# Altium schematic sheet Esquematico LEDS.SchDoc("Esquematico LEDS")
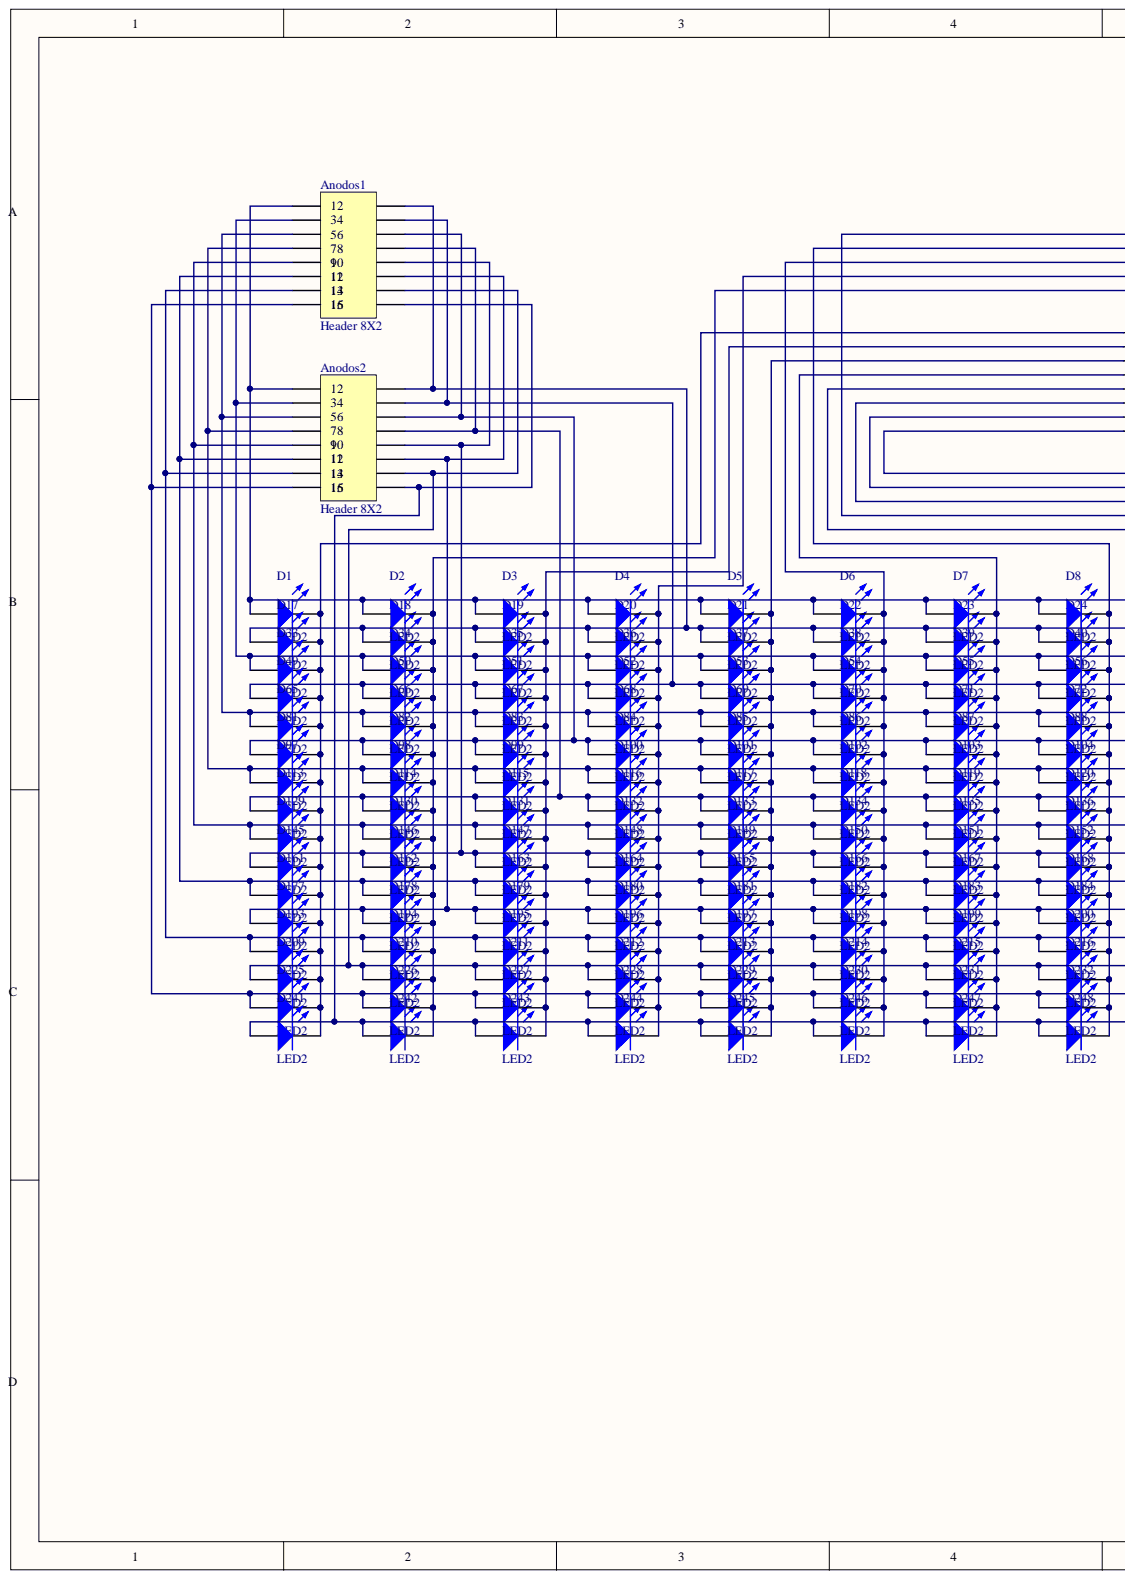
[diagram p0: Esquematico LEDS.SchDoc, left]
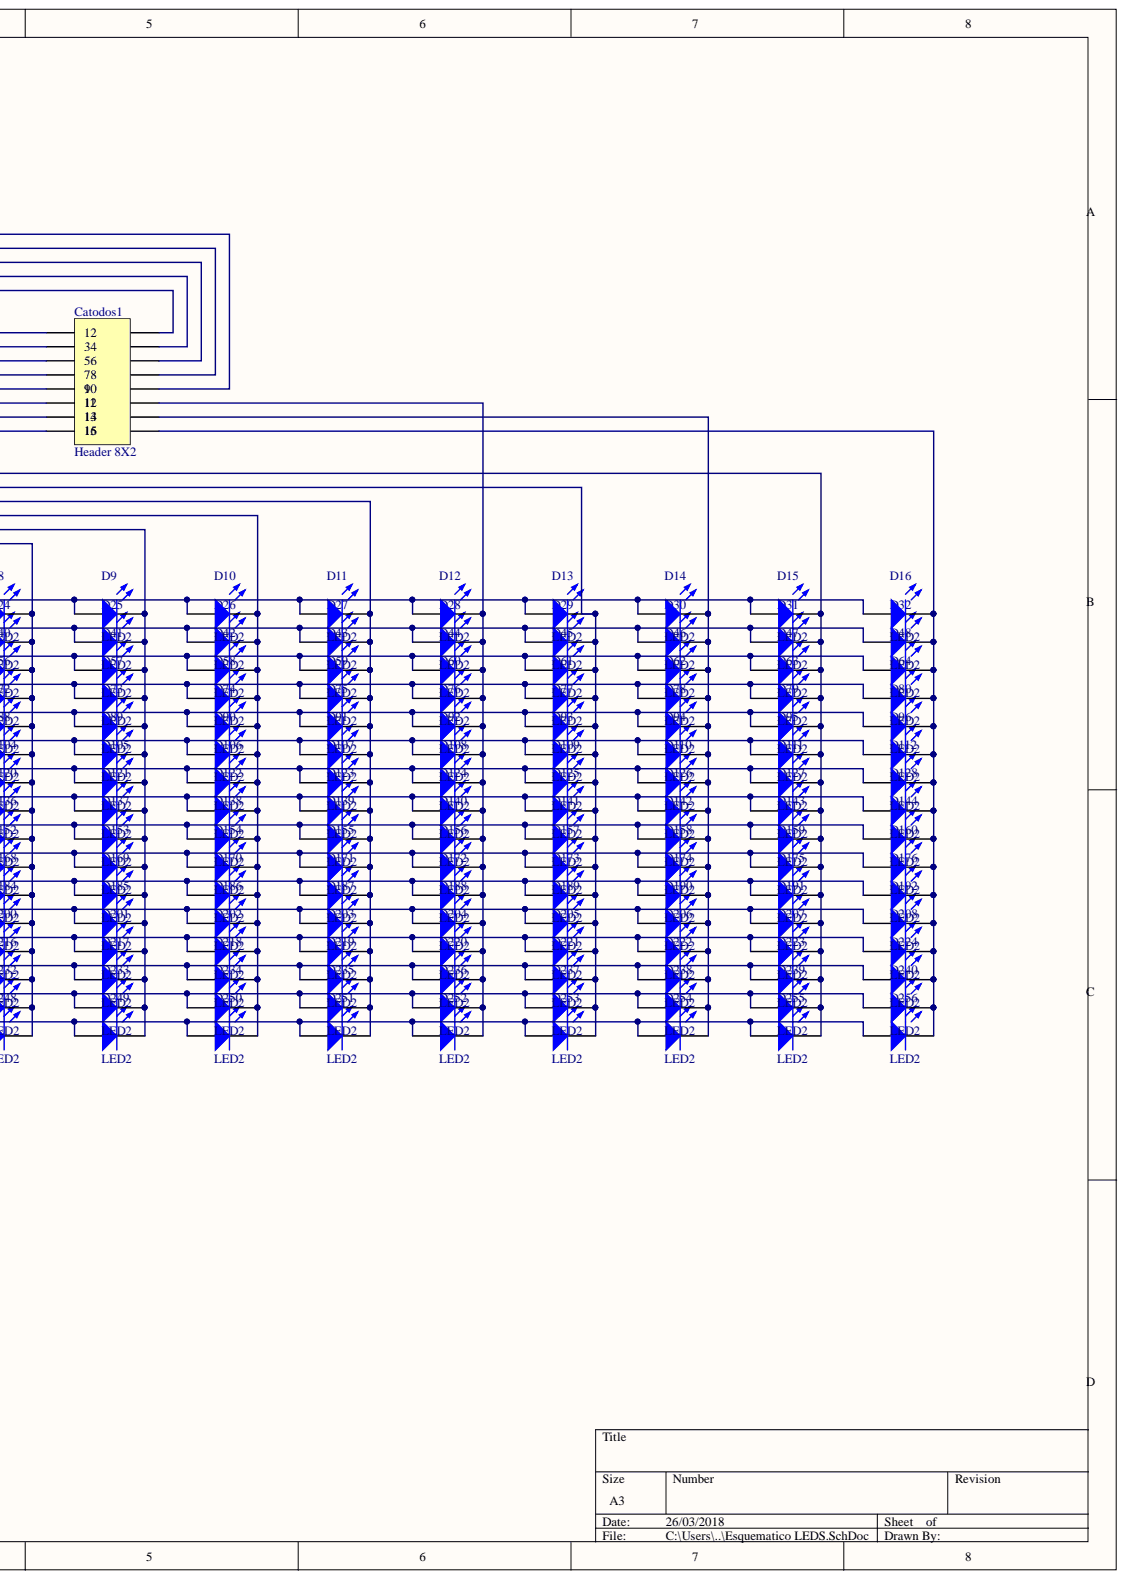
[diagram p1: Esquematico LEDS.SchDoc, right]

## Parts
Anodos1  Header 8X2  [HDR2X8]
Anodos2  Header 8X2  [HDR2X8]
Catodos1  Header 8X2  [HDR2X8]
D1  LED2  [3.2X1.6X1.1]
D2  LED2  [3.2X1.6X1.1]
D3  LED2  [3.2X1.6X1.1]
D4  LED2  [3.2X1.6X1.1]
D5  LED2  [3.2X1.6X1.1]
D6  LED2  [3.2X1.6X1.1]
D7  LED2  [3.2X1.6X1.1]
D8  LED2  [3.2X1.6X1.1]
D9  LED2  [3.2X1.6X1.1]
D10  LED2  [3.2X1.6X1.1]
D11  LED2  [3.2X1.6X1.1]
D12  LED2  [3.2X1.6X1.1]
D13  LED2  [3.2X1.6X1.1]
D14  LED2  [3.2X1.6X1.1]
D15  LED2  [3.2X1.6X1.1]
D16  LED2  [3.2X1.6X1.1]
D17  LED2  [3.2X1.6X1.1]
D18  LED2  [3.2X1.6X1.1]
D19  LED2  [3.2X1.6X1.1]
D20  LED2  [3.2X1.6X1.1]
D21  LED2  [3.2X1.6X1.1]
D22  LED2  [3.2X1.6X1.1]
D23  LED2  [3.2X1.6X1.1]
D24  LED2  [3.2X1.6X1.1]
D25  LED2  [3.2X1.6X1.1]
D26  LED2  [3.2X1.6X1.1]
D27  LED2  [3.2X1.6X1.1]
D28  LED2  [3.2X1.6X1.1]
D29  LED2  [3.2X1.6X1.1]
D30  LED2  [3.2X1.6X1.1]
D31  LED2  [3.2X1.6X1.1]
D32  LED2  [3.2X1.6X1.1]
D33  LED2  [3.2X1.6X1.1]
D34  LED2  [3.2X1.6X1.1]
D35  LED2  [3.2X1.6X1.1]
D36  LED2  [3.2X1.6X1.1]
D37  LED2  [3.2X1.6X1.1]
D38  LED2  [3.2X1.6X1.1]
D39  LED2  [3.2X1.6X1.1]
D40  LED2  [3.2X1.6X1.1]
D41  LED2  [3.2X1.6X1.1]
D42  LED2  [3.2X1.6X1.1]
D43  LED2  [3.2X1.6X1.1]
D44  LED2  [3.2X1.6X1.1]
D45  LED2  [3.2X1.6X1.1]
D46  LED2  [3.2X1.6X1.1]
D47  LED2  [3.2X1.6X1.1]
D48  LED2  [3.2X1.6X1.1]
D49  LED2  [3.2X1.6X1.1]
D50  LED2  [3.2X1.6X1.1]
D51  LED2  [3.2X1.6X1.1]
D52  LED2  [3.2X1.6X1.1]
D53  LED2  [3.2X1.6X1.1]
D54  LED2  [3.2X1.6X1.1]
D55  LED2  [3.2X1.6X1.1]
D56  LED2  [3.2X1.6X1.1]
D57  LED2  [3.2X1.6X1.1]
D58  LED2  [3.2X1.6X1.1]
D59  LED2  [3.2X1.6X1.1]
D60  LED2  [3.2X1.6X1.1]
D61  LED2  [3.2X1.6X1.1]
D62  LED2  [3.2X1.6X1.1]
D63  LED2  [3.2X1.6X1.1]
D64  LED2  [3.2X1.6X1.1]
D65  LED2  [3.2X1.6X1.1]
D66  LED2  [3.2X1.6X1.1]
D67  LED2  [3.2X1.6X1.1]
D68  LED2  [3.2X1.6X1.1]
D69  LED2  [3.2X1.6X1.1]
D70  LED2  [3.2X1.6X1.1]
D71  LED2  [3.2X1.6X1.1]
D72  LED2  [3.2X1.6X1.1]
D73  LED2  [3.2X1.6X1.1]
D74  LED2  [3.2X1.6X1.1]
D75  LED2  [3.2X1.6X1.1]
D76  LED2  [3.2X1.6X1.1]
D77  LED2  [3.2X1.6X1.1]
D78  LED2  [3.2X1.6X1.1]
D79  LED2  [3.2X1.6X1.1]
D80  LED2  [3.2X1.6X1.1]
D81  LED2  [3.2X1.6X1.1]
D82  LED2  [3.2X1.6X1.1]
D83  LED2  [3.2X1.6X1.1]
D84  LED2  [3.2X1.6X1.1]
D85  LED2  [3.2X1.6X1.1]
D86  LED2  [3.2X1.6X1.1]
D87  LED2  [3.2X1.6X1.1]
D88  LED2  [3.2X1.6X1.1]
D89  LED2  [3.2X1.6X1.1]
D90  LED2  [3.2X1.6X1.1]
D91  LED2  [3.2X1.6X1.1]
D92  LED2  [3.2X1.6X1.1]
D93  LED2  [3.2X1.6X1.1]
D94  LED2  [3.2X1.6X1.1]
D95  LED2  [3.2X1.6X1.1]
D96  LED2  [3.2X1.6X1.1]
D97  LED2  [3.2X1.6X1.1]
D98  LED2  [3.2X1.6X1.1]
D99  LED2  [3.2X1.6X1.1]
D100  LED2  [3.2X1.6X1.1]
D101  LED2  [3.2X1.6X1.1]
D102  LED2  [3.2X1.6X1.1]
D103  LED2  [3.2X1.6X1.1]
D104  LED2  [3.2X1.6X1.1]
D105  LED2  [3.2X1.6X1.1]
D106  LED2  [3.2X1.6X1.1]
D107  LED2  [3.2X1.6X1.1]
D108  LED2  [3.2X1.6X1.1]
D109  LED2  [3.2X1.6X1.1]
D110  LED2  [3.2X1.6X1.1]
D111  LED2  [3.2X1.6X1.1]
D112  LED2  [3.2X1.6X1.1]
D113  LED2  [3.2X1.6X1.1]
D114  LED2  [3.2X1.6X1.1]
D115  LED2  [3.2X1.6X1.1]
D116  LED2  [3.2X1.6X1.1]
D117  LED2  [3.2X1.6X1.1]
D118  LED2  [3.2X1.6X1.1]
D119  LED2  [3.2X1.6X1.1]
D120  LED2  [3.2X1.6X1.1]
D121  LED2  [3.2X1.6X1.1]
D122  LED2  [3.2X1.6X1.1]
D123  LED2  [3.2X1.6X1.1]
D124  LED2  [3.2X1.6X1.1]
D125  LED2  [3.2X1.6X1.1]
D126  LED2  [3.2X1.6X1.1]
D127  LED2  [3.2X1.6X1.1]
D128  LED2  [3.2X1.6X1.1]
D129  LED2  [3.2X1.6X1.1]
D130  LED2  [3.2X1.6X1.1]
D131  LED2  [3.2X1.6X1.1]
D132  LED2  [3.2X1.6X1.1]
D133  LED2  [3.2X1.6X1.1]
D134  LED2  [3.2X1.6X1.1]
D135  LED2  [3.2X1.6X1.1]
D136  LED2  [3.2X1.6X1.1]
D137  LED2  [3.2X1.6X1.1]
D138  LED2  [3.2X1.6X1.1]
D139  LED2  [3.2X1.6X1.1]
D140  LED2  [3.2X1.6X1.1]
D141  LED2  [3.2X1.6X1.1]
D142  LED2  [3.2X1.6X1.1]
D143  LED2  [3.2X1.6X1.1]
D144  LED2  [3.2X1.6X1.1]
D145  LED2  [3.2X1.6X1.1]
D146  LED2  [3.2X1.6X1.1]
D147  LED2  [3.2X1.6X1.1]
D148  LED2  [3.2X1.6X1.1]
D149  LED2  [3.2X1.6X1.1]
D150  LED2  [3.2X1.6X1.1]
D151  LED2  [3.2X1.6X1.1]
D152  LED2  [3.2X1.6X1.1]
D153  LED2  [3.2X1.6X1.1]
D154  LED2  [3.2X1.6X1.1]
D155  LED2  [3.2X1.6X1.1]
D156  LED2  [3.2X1.6X1.1]
D157  LED2  [3.2X1.6X1.1]
D158  LED2  [3.2X1.6X1.1]
D159  LED2  [3.2X1.6X1.1]
D160  LED2  [3.2X1.6X1.1]
D161  LED2  [3.2X1.6X1.1]
D162  LED2  [3.2X1.6X1.1]
D163  LED2  [3.2X1.6X1.1]
D164  LED2  [3.2X1.6X1.1]
D165  LED2  [3.2X1.6X1.1]
D166  LED2  [3.2X1.6X1.1]
D167  LED2  [3.2X1.6X1.1]
D168  LED2  [3.2X1.6X1.1]
D169  LED2  [3.2X1.6X1.1]
D170  LED2  [3.2X1.6X1.1]
D171  LED2  [3.2X1.6X1.1]
D172  LED2  [3.2X1.6X1.1]
D173  LED2  [3.2X1.6X1.1]
D174  LED2  [3.2X1.6X1.1]
D175  LED2  [3.2X1.6X1.1]
D176  LED2  [3.2X1.6X1.1]
D177  LED2  [3.2X1.6X1.1]
D178  LED2  [3.2X1.6X1.1]
D179  LED2  [3.2X1.6X1.1]
D180  LED2  [3.2X1.6X1.1]
D181  LED2  [3.2X1.6X1.1]
D182  LED2  [3.2X1.6X1.1]
D183  LED2  [3.2X1.6X1.1]
D184  LED2  [3.2X1.6X1.1]
D185  LED2  [3.2X1.6X1.1]
D186  LED2  [3.2X1.6X1.1]
D187  LED2  [3.2X1.6X1.1]
D188  LED2  [3.2X1.6X1.1]
D189  LED2  [3.2X1.6X1.1]
D190  LED2  [3.2X1.6X1.1]
D191  LED2  [3.2X1.6X1.1]
D192  LED2  [3.2X1.6X1.1]
D193  LED2  [3.2X1.6X1.1]
D194  LED2  [3.2X1.6X1.1]
D195  LED2  [3.2X1.6X1.1]
D196  LED2  [3.2X1.6X1.1]
D197  LED2  [3.2X1.6X1.1]
D198  LED2  [3.2X1.6X1.1]
D199  LED2  [3.2X1.6X1.1]
D200  LED2  [3.2X1.6X1.1]
D201  LED2  [3.2X1.6X1.1]
D202  LED2  [3.2X1.6X1.1]
D203  LED2  [3.2X1.6X1.1]
D204  LED2  [3.2X1.6X1.1]
D205  LED2  [3.2X1.6X1.1]
D206  LED2  [3.2X1.6X1.1]
D207  LED2  [3.2X1.6X1.1]
D208  LED2  [3.2X1.6X1.1]
D209  LED2  [3.2X1.6X1.1]
D210  LED2  [3.2X1.6X1.1]
D211  LED2  [3.2X1.6X1.1]
D212  LED2  [3.2X1.6X1.1]
D213  LED2  [3.2X1.6X1.1]
D214  LED2  [3.2X1.6X1.1]
D215  LED2  [3.2X1.6X1.1]
D216  LED2  [3.2X1.6X1.1]
D217  LED2  [3.2X1.6X1.1]
D218  LED2  [3.2X1.6X1.1]
D219  LED2  [3.2X1.6X1.1]
D220  LED2  [3.2X1.6X1.1]
D221  LED2  [3.2X1.6X1.1]
D222  LED2  [3.2X1.6X1.1]
D223  LED2  [3.2X1.6X1.1]
D224  LED2  [3.2X1.6X1.1]
D225  LED2  [3.2X1.6X1.1]
D226  LED2  [3.2X1.6X1.1]
D227  LED2  [3.2X1.6X1.1]
D228  LED2  [3.2X1.6X1.1]
D229  LED2  [3.2X1.6X1.1]
D230  LED2  [3.2X1.6X1.1]
D231  LED2  [3.2X1.6X1.1]
D232  LED2  [3.2X1.6X1.1]
D233  LED2  [3.2X1.6X1.1]
D234  LED2  [3.2X1.6X1.1]
D235  LED2  [3.2X1.6X1.1]
D236  LED2  [3.2X1.6X1.1]
D237  LED2  [3.2X1.6X1.1]
D238  LED2  [3.2X1.6X1.1]
D239  LED2  [3.2X1.6X1.1]
D240  LED2  [3.2X1.6X1.1]
D241  LED2  [3.2X1.6X1.1]
D242  LED2  [3.2X1.6X1.1]
D243  LED2  [3.2X1.6X1.1]
D244  LED2  [3.2X1.6X1.1]
D245  LED2  [3.2X1.6X1.1]
D246  LED2  [3.2X1.6X1.1]
D247  LED2  [3.2X1.6X1.1]
D248  LED2  [3.2X1.6X1.1]
D249  LED2  [3.2X1.6X1.1]
D250  LED2  [3.2X1.6X1.1]
D251  LED2  [3.2X1.6X1.1]
D252  LED2  [3.2X1.6X1.1]
D253  LED2  [3.2X1.6X1.1]
D254  LED2  [3.2X1.6X1.1]
D255  LED2  [3.2X1.6X1.1]
D256  LED2  [3.2X1.6X1.1]

## Nets
NetAnodos1_1: Anodos1.1 Anodos2.1 D1.1 D2.1 D3.1 D4.1 D5.1 D6.1 D7.1 D8.1 D9.1 D10.1 D11.1 D12.1 D13.1 D14.1 D15.1 D16.1
NetAnodos1_2: Anodos1.2 Anodos2.2 D17.1 D18.1 D19.1 D20.1 D21.1 D22.1 D23.1 D24.1 D25.1 D26.1 D27.1 D28.1 D29.1 D30.1 D31.1 D32.1
NetAnodos1_3: Anodos1.3 Anodos2.3 D33.1 D34.1 D35.1 D36.1 D37.1 D38.1 D39.1 D40.1 D41.1 D42.1 D43.1 D44.1 D45.1 D46.1 D47.1 D48.1
NetAnodos1_4: Anodos1.4 Anodos2.4 D49.1 D50.1 D51.1 D52.1 D53.1 D54.1 D55.1 D56.1 D57.1 D58.1 D59.1 D60.1 D61.1 D62.1 D63.1 D64.1
NetAnodos1_5: Anodos1.5 Anodos2.5 D65.1 D66.1 D67.1 D68.1 D69.1 D70.1 D71.1 D72.1 D73.1 D74.1 D75.1 D76.1 D77.1 D78.1 D79.1 D80.1
NetAnodos1_6: Anodos1.6 Anodos2.6 D81.1 D82.1 D83.1 D84.1 D85.1 D86.1 D87.1 D88.1 D89.1 D90.1 D91.1 D92.1 D93.1 D94.1 D95.1 D96.1
NetAnodos1_7: Anodos1.7 Anodos2.7 D97.1 D98.1 D99.1 D100.1 D101.1 D102.1 D103.1 D104.1 D105.1 D106.1 D107.1 D108.1 D109.1 D110.1 D111.1 D112.1
NetAnodos1_8: Anodos1.8 Anodos2.8 D113.1 D114.1 D115.1 D116.1 D117.1 D118.1 D119.1 D120.1 D121.1 D122.1 D123.1 D124.1 D125.1 D126.1 D127.1 D128.1
NetAnodos1_9: Anodos1.9 Anodos2.9 D129.1 D130.1 D131.1 D132.1 D133.1 D134.1 D135.1 D136.1 D137.1 D138.1 D139.1 D140.1 D141.1 D142.1 D143.1 D144.1
NetAnodos1_10: Anodos1.10 Anodos2.10 D145.1 D146.1 D147.1 D148.1 D149.1 D150.1 D151.1 D152.1 D153.1 D154.1 D155.1 D156.1 D157.1 D158.1 D159.1 D160.1
NetAnodos1_11: Anodos1.11 Anodos2.11 D161.1 D162.1 D163.1 D164.1 D165.1 D166.1 D167.1 D168.1 D169.1 D170.1 D171.1 D172.1 D173.1 D174.1 D175.1 D176.1
NetAnodos1_12: Anodos1.12 Anodos2.12 D177.1 D178.1 D179.1 D180.1 D181.1 D182.1 D183.1 D184.1 D185.1 D186.1 D187.1 D188.1 D189.1 D190.1 D191.1 D192.1
NetAnodos1_13: Anodos1.13 Anodos2.13 D193.1 D194.1 D195.1 D196.1 D197.1 D198.1 D199.1 D200.1 D201.1 D202.1 D203.1 D204.1 D205.1 D206.1 D207.1 D208.1
NetAnodos1_14: Anodos1.14 Anodos2.14 D209.1 D210.1 D211.1 D212.1 D213.1 D214.1 D215.1 D216.1 D217.1 D218.1 D219.1 D220.1 D221.1 D222.1 D223.1 D224.1
NetAnodos1_15: Anodos1.15 Anodos2.15 D225.1 D226.1 D227.1 D228.1 D229.1 D230.1 D231.1 D232.1 D233.1 D234.1 D235.1 D236.1 D237.1 D238.1 D239.1 D240.1
NetAnodos1_16: Anodos1.16 Anodos2.16 D241.1 D242.1 D243.1 D244.1 D245.1 D246.1 D247.1 D248.1 D249.1 D250.1 D251.1 D252.1 D253.1 D254.1 D255.1 D256.1
NetCatodos1_1: Catodos1.1 D1.2 D17.2 D33.2 D49.2 D65.2 D81.2 D97.2 D113.2 D129.2 D145.2 D161.2 D177.2 D193.2 D209.2 D225.2 D241.2
NetCatodos1_2: Catodos1.2 D2.2 D18.2 D34.2 D50.2 D66.2 D82.2 D98.2 D114.2 D130.2 D146.2 D162.2 D178.2 D194.2 D210.2 D226.2 D242.2
NetCatodos1_3: Catodos1.3 D3.2 D19.2 D35.2 D51.2 D67.2 D83.2 D99.2 D115.2 D131.2 D147.2 D163.2 D179.2 D195.2 D211.2 D227.2 D243.2
NetCatodos1_4: Catodos1.4 D4.2 D20.2 D36.2 D52.2 D68.2 D84.2 D100.2 D116.2 D132.2 D148.2 D164.2 D180.2 D196.2 D212.2 D228.2 D244.2
NetCatodos1_5: Catodos1.5 D5.2 D21.2 D37.2 D53.2 D69.2 D85.2 D101.2 D117.2 D133.2 D149.2 D165.2 D181.2 D197.2 D213.2 D229.2 D245.2
NetCatodos1_6: Catodos1.6 D6.2 D22.2 D38.2 D54.2 D70.2 D86.2 D102.2 D118.2 D134.2 D150.2 D166.2 D182.2 D198.2 D214.2 D230.2 D246.2
NetCatodos1_7: Catodos1.7 D7.2 D23.2 D39.2 D55.2 D71.2 D87.2 D103.2 D119.2 D135.2 D151.2 D167.2 D183.2 D199.2 D215.2 D231.2 D247.2
NetCatodos1_8: Catodos1.8 D8.2 D24.2 D40.2 D56.2 D72.2 D88.2 D104.2 D120.2 D136.2 D152.2 D168.2 D184.2 D200.2 D216.2 D232.2 D248.2
NetCatodos1_9: Catodos1.9 D9.2 D25.2 D41.2 D57.2 D73.2 D89.2 D105.2 D121.2 D137.2 D153.2 D169.2 D185.2 D201.2 D217.2 D233.2 D249.2
NetCatodos1_10: Catodos1.10 D10.2 D26.2 D42.2 D58.2 D74.2 D90.2 D106.2 D122.2 D138.2 D154.2 D170.2 D186.2 D202.2 D218.2 D234.2 D250.2
NetCatodos1_11: Catodos1.11 D11.2 D27.2 D43.2 D59.2 D75.2 D91.2 D107.2 D123.2 D139.2 D155.2 D171.2 D187.2 D203.2 D219.2 D235.2 D251.2
NetCatodos1_12: Catodos1.12 D12.2 D28.2 D44.2 D60.2 D76.2 D92.2 D108.2 D124.2 D140.2 D156.2 D172.2 D188.2 D204.2 D220.2 D236.2 D252.2
NetCatodos1_13: Catodos1.13 D13.2 D29.2 D45.2 D61.2 D77.2 D93.2 D109.2 D125.2 D141.2 D157.2 D173.2 D189.2 D205.2 D221.2 D237.2 D253.2
NetCatodos1_14: Catodos1.14 D14.2 D30.2 D46.2 D62.2 D78.2 D94.2 D110.2 D126.2 D142.2 D158.2 D174.2 D190.2 D206.2 D222.2 D238.2 D254.2
NetCatodos1_15: Catodos1.15 D15.2 D31.2 D47.2 D63.2 D79.2 D95.2 D111.2 D127.2 D143.2 D159.2 D175.2 D191.2 D207.2 D223.2 D239.2 D255.2
NetCatodos1_16: Catodos1.16 D16.2 D32.2 D48.2 D64.2 D80.2 D96.2 D112.2 D128.2 D144.2 D160.2 D176.2 D192.2 D208.2 D224.2 D240.2 D256.2

## Library data of the parts
Anodos1 Anodos2 Catodos1 = Header 8X2: Header, 8-Pin, Dual row; Component Kind: Standard; LatestRevisionDate: 17-Jul-2002; LatestRevisionNote: Re-released for DXP Platform.; Library Name: Miscellaneous Connectors.IntLib; Signal Integrity: Connector; Publisher: Altium Limited
D1-D256 = LED2: Typical RED, GREEN, YELLOW, AMBER GaAs LED; Component Kind: Standard; LatestRevisionDate: 17-Jul-2002; LatestRevisionNote: Re-released for DXP Platform.; Library Name: Miscellaneous Devices.IntLib; PackageDescription: SM LED; 2 Flat Leads; PackageReference: 3.2X1.6X1.1; PackageVersion: Feb-2002; Published: 8-Jun-2000; Simulation: LED2; Publisher: Altium Limited
